# Altium schematic sheet 串口扩展.SchDoc(串口扩展)
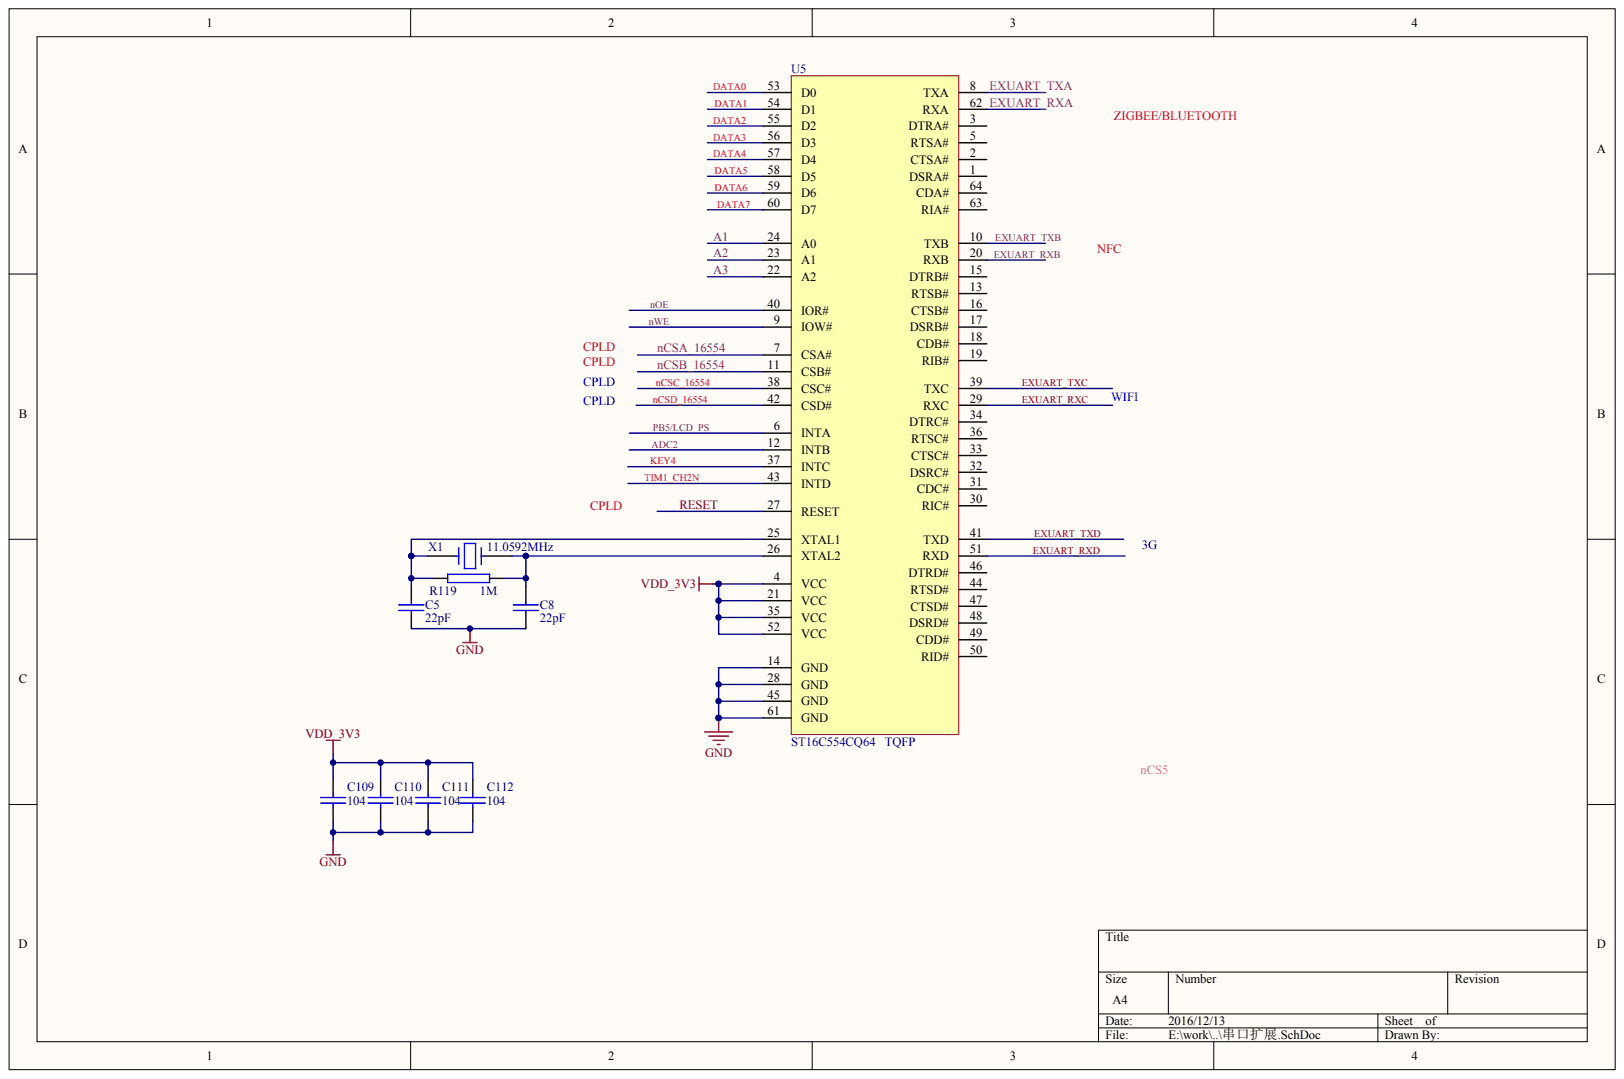
[diagram p0: 串口扩展.SchDoc]

## Parts
C5  22pF
C8  22pF
C109  104
C110  104
C111  104
C112  104
R119  1M
U5  ST16C554CQ64   TQFP
X1  11.0592MHz

## Nets
GND: C5.2 C8.2 C109.2 C110.2 C111.2 C112.2 U5.14(GND) U5.28(GND) U5.45(GND) U5.61(GND)
VDD_3V3: C109.1 C110.1 C111.1 C112.1 U5.4(VCC) U5.21(VCC) U5.35(VCC) U5.52(VCC)
A1: U5.24(A0)
A2: U5.23(A1)
A3: U5.22(A2)
ADC2: U5.12(INTB)
DATA0: U5.53(D0)
DATA1: U5.54(D1)
DATA2: U5.55(D2)
DATA3: U5.56(D3)
DATA4: U5.57(D4)
DATA5: U5.58(D5)
DATA6: U5.59(D6)
DATA7: U5.60(D7)
EXUART_RXA: U5.62(RXA)
EXUART_RXB: U5.20(RXB)
EXUART_RXC: U5.29(RXC)
EXUART_RXD: U5.51(RXD)
EXUART_TXA: U5.8(TXA)
EXUART_TXB: U5.10(TXB)
EXUART_TXC: U5.39(TXC)
EXUART_TXD: U5.41(TXD)
KEY4: U5.37(INTC)
NCSA_16554: U5.7(CSA#)
NCSB_16554: U5.11(CSB#)
NCSC_16554: U5.38(CSC#)
NCSD_16554: U5.42(CSD#)
NOE: U5.40(IOR#)
NWE: U5.9(IOW#)
PB5/LCD_PS: U5.6(INTA)
RESET: U5.27(RESET)
TIM1_CH2N: U5.43(INTD)
NetC5_1: C5.1 R119.2 U5.25(XTAL1) X1.1
NetC8_1: C8.1 R119.1 U5.26(XTAL2) X1.2

Not connected: U5 1(DSRA#) 2(CTSA#) 3(DTRA#) 5(RTSA#) 13(RTSB#) 15(DTRB#) 16(CTSB#) 17(DSRB#) 18(CDB#) 19(RIB#) 30(RIC#) 31(CDC#) 32(DSRC#) 33(CTSC#) 34(DTRC#) 36(RTSC#) 44(RTSD#) 46(DTRD#) 47(CTSD#) 48(DSRD#) 49(CDD#) 50(RID#) 63(RIA#) 64(CDA#)

## Library data of the parts
C5 C8 = (no symbol name): Text Field1: CAPACITOR
